# Altium schematic sheet Data_Acquisition.SchDoc
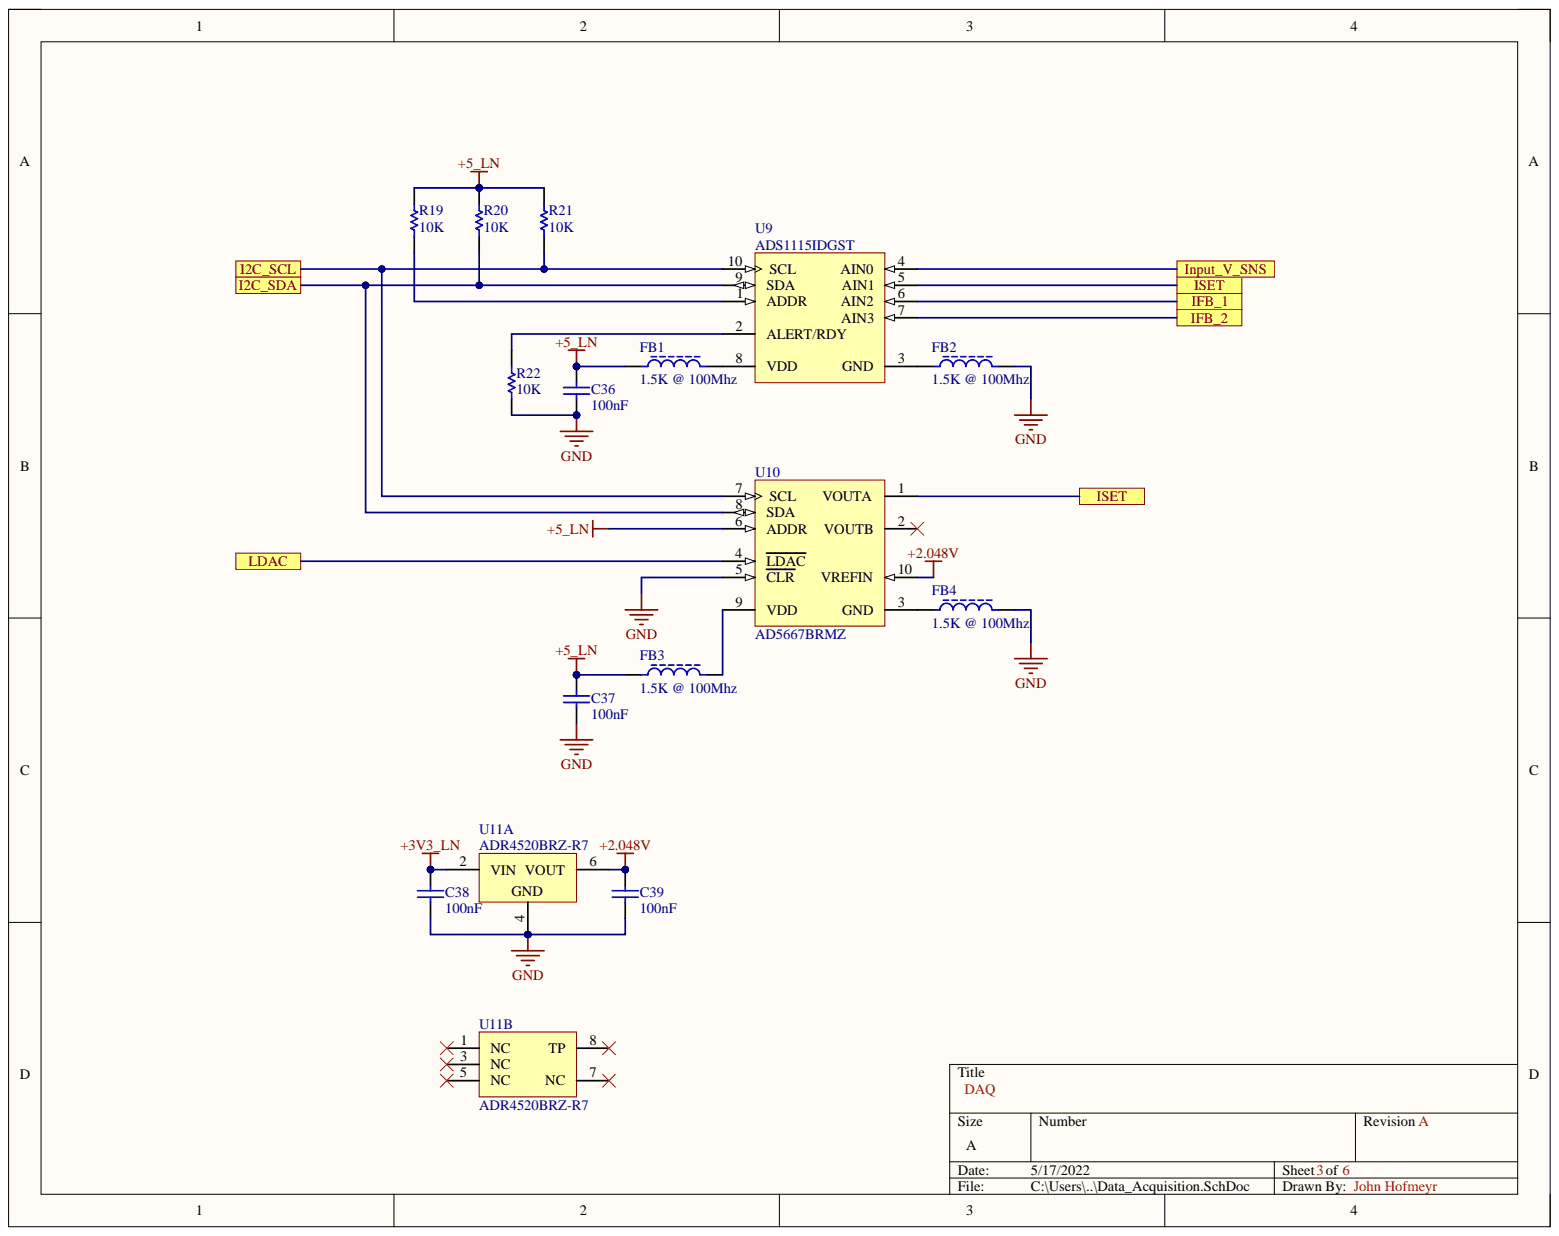
[diagram p0: Data_Acquisition.SchDoc]

## Parts
C36  100nF  [CAPC2013X140X50ML20T25]
C37  100nF  [CAPC2013X140X50ML20T25]
C38  100nF  [CAPC2013X140X50ML20T25]
C39  100nF  [CAPC2013X140X50ML20T25]
FB1  1.5K @ 100Mhz  [R0805]
FB2  1.5K @ 100Mhz  [R0805]
FB3  1.5K @ 100Mhz  [R0805]
FB4  1.5K @ 100Mhz  [R0805]
R19  10K  [RESC2013X50X40NL20T20]
R20  10K  [RESC2013X50X40NL20T20]
R21  10K  [RESC2013X50X40NL20T20]
R22  10K  [RESC2013X50X40NL20T20]
U9  ADS1115IDGST  [TI-DGS10_M]
U10  AD5667BRMZ  [RM-10_M]
U11  ADR4520BRZ-R7  [ADI-R-8_M]  (parts A,B)

## Ports
I2C_SCL, I2C_SDA, IFB_1, IFB_2, Input_V_SNS, ISET, LDAC

## Nets
+2.048V: C39.2 U10.10(VREFIN) U11.6(VOUT)
+3V3_LN: C38.2 U11.2(VIN)
+5_LN: C36.2 C37.2 FB1.1 FB3.1 R19.2 R20.2 R21.2 U10.6(ADDR)
GND: C36.1 C37.1 C38.1 C39.1 FB2.2 FB4.2 R22.2 U10.5(CLR) U11.4
I2C_SCL: R21.1 U9.10(SCL) U10.7(SCL) ; port I2C_SCL
I2C_SDA: R20.1 U9.9(SDA) U10.8(SDA) ; port I2C_SDA
IFB_1: U9.6(AIN2) ; port IFB_1
IFB_2: U9.7(AIN3) ; port IFB_2
Input_V_SNS: U9.4(AIN0) ; port Input_V_SNS
ISET: U9.5(AIN1) U10.1(VOUTA) ; port ISET
LDAC: U10.4(LDAC) ; port LDAC
NetFB1_2: FB1.2 U9.8(VDD)
NetFB2_1: FB2.1 U9.3(GND)
NetFB3_2: FB3.2 U10.9(VDD)
NetFB4_1: FB4.1 U10.3(GND)
NetR19_1: R19.1 U9.1(ADDR)
NetR22_1: R22.1 U9.2(ALERT/RDY)

Not connected: U10 2(VOUTB); U11 1(NC) 3(NC) 5(NC) 7(NC) 8(TP)

## Library data of the parts
C36-C39 = CMP-2007-04689-1: Manufacturer: AVX Interconnect / Elco; Part Number: 08055D104KAT2A; Component Kind: Standard; Library Name: Altium Content Vault
FB1-FB4 = MMZ2012Y152BTD25: FERRITE BEAD 1.5 KOHM 0805 1LN; Manufacturer: TDK; DC Resistance (DCR): 400mR; Impedance: 1.5kR; Max Current Rating: 500mA; Number of Lines: 1; Tolerance: 25%; Case Code (Imperial): 0805; Case Code (Metric): 2012; Case/Package: 0805; Category: Ferrite Beads and Chips; Component Kind: Standard; ComponentLink1Description: https://media.digikey.com/pdf/Data%20Sheets/TDK%20PDFs/MMZ_Series_Br.pdf?src-supplier=Digi-Key; ComponentLink1URL: https://media.digikey.com/pdf/Data%20Sheets/TDK%20PDFs/MMZ_Series_Br.pdf?src-supplier=Digi-Key; ComponentLink2Description: http://www.mouser.com/ds/2/400/beads_automotive_signal_mmz_en-469244.pdf?src-supplier=Mouser; ComponentLink2URL: http://www.mouser.com/ds/2/400/beads_automotive_signal_mmz_en-469244.pdf?src-supplier=Mouser; ComponentLink3Description: https://product.tdk.com/info/en/catalog/datasheets/beads_automotive_signal_mmz2012_en.pdf?src-supplier=Digi-Key; ComponentLink3URL: https://product.tdk.com/info/en/catalog/datasheets/beads_automotive_signal_mmz2012_en.pdf?src-supplier=Digi-Key; Height: 0.041inch; Length: 0.079inch; Library Name: DCLoadV2.SchLib; Manufacturer Part Number: MMZ2012Y152BTD25; Max DC Current: 500mA; Max Operating Temperature: 125°C; Min Operating Temperature: -55°C; Mount: Surface Mount; Package Quantity: 4000; Packaging: Tape and Reel; Pricing 1: 1=0.11000, 10=0.09100, 25=0.07080, 50=0.05860, 100=0.05060, 250=0.04048, 500=0.03644, 1000=0.03036 (USD); Ratings: AEC-Q200; RoHS: Yes; Stock 1: 4172; Supplier 1: Digi-Key; Supplier Part Number 1: 445-172871-1-ND; Termination: SMD/SMT; Test Frequency: 100MHz; Weight: 0.000194oz; Width: 0.049inch
R19-R22 = CMP-2001-03215-1: Manufacturer: Susumu; Part Number: RR1220P-103-D; Component Kind: Standard; Library Name: Altium Content Vault
U9 = CMP-0003-00378-2: 16-Bit ADC with Integrated Multiplexer, PGA, Comparator, Oscillator, and Reference, -40 to 125 degC, 10-pin SOP (DGS10), Green (RoHS & no Sb/Br); Manufacturer: Texas Instruments; # Input Channels: 4; Analog Voltage AV/DD(Max)(V): 5.5; Analog Voltage AV/DD(Min)(V): 2; Architecture: Delta-Sigma; INL(Max)(+/-LSB): 1; Interface: Serial I2C; Resolution(Bits): 16; Sample Rate (max)(SPS): 860; SubFamily: Precision ADC (<=10MSPS); Code_JEDEC: MO-153; Component Kind: Standard; ComponentLink1Description: Manufacturer URL; ComponentLink1URL: http://www.ti.com/; ComponentLink2Description: Datasheet; ComponentLink2URL: http://www.ti.com/general/docs/lit/getliterature.tsp?genericPartNumber=ADS1115&fileType=pdf; ComponentLink3Description: Package Specification; ComponentLink3URL: http://www.ti.com/lit/ml/mpds035b/mpds035b.pdf; DatasheetVersion: SBAS444B; Digital Supply(Max)(V): 5.5; Digital Supply(Min)(V): 2; Eco-Plan: Green (RoHS & no Sb/Br); Library Name: Altium Content Vault; Mounting Technology: Surface Mount; PackageDescription: 10-Pin Plastic Small Outline, Body 3 x 3 mm, Pitch 0.5 mm; PackageReference: DGS10; PackageVersion: 4073272/C, 02/2004; PartNumber: ADS1115IDGST
U10 = CMP-0318-02093-2: Dual 16-Bit nanoDAC with I2C Interface, -40 to +105 degC, RM-10, Pb-Free, Tube; Generic Part Number: AD5667; Manufacturer: Analog Devices; # DAC Outputs: 2; Max V+: 5.5V; Op Pwr Diss: 5.75mW; Resolution (Bits): 16; Settling Time: 4Âµs; Single Supply: Yes; Component Kind: Standard; ComponentLink1Description: Manufacturer URL; ComponentLink1URL: http://www.analog.com/en/index.html; ComponentLink2Description: Datasheet; ComponentLink2URL: http://www.analog.com/static/imported-files/data_sheets/AD5627R_5647R_5667R_5627_5667.pdf; ComponentLink3Description: Package Specification; ComponentLink3URL: http://www.analog.com/static/imported-files/packages/PKG_PDF/MSOP%28RM%29/RM_10.pdf; ComponentLink4Description: Product URL; ComponentLink4URL: http://www.analog.com/en/digital-to-analog-converters/da-converters/ad5667/products/product.html; DatasheetVersion: Rev.0; Library Name: Altium Content Vault; Mounting Technology: Surface Mount; PackageDescription: 10-Lead Mini Small Outline Package [MSOP]; PackageReference: RM-10; PackageVersion: May-2005; Packing: Tube; PartNumber: AD5667BRMZ; RevisionNote: Component URL fixed; RoHS: Y
U11 = CMP-0784-00295-1: Ultralow Noise, High Accuracy Voltage Reference, 2.048 V Output, 3 to 15 V Supply, Industrial, 8-pin SOIC (R-8), Reel; Manufacturer: Analog Devices; Max V Supply (V): 15; Min V Supply (V): 3; Ref Out TC (ppm/C): 2; Vout: 2.048V; Code_JEDEC: MS-012-AA; Component Kind: Standard; ComponentLink1Description: Manufacturer URL; ComponentLink1URL: http://www.analog.com/en/index.html; ComponentLink2Description: Datasheet; ComponentLink2URL: http://www.analog.com/static/imported-files/data_sheets/ADR4520_4525_4530_4533_4540_4550.pdf; ComponentLink3Description: Package Specification; ComponentLink3URL: http://www.analog.com/static/imported-files/packages/PKG_PDF/SOIC_Narrow%20(R)/R_8.pdf; ComponentLink4Description: URL Link1; ComponentLink4URL: Product URL:http://www.analog.com/en/special-linear-functions/voltage-references/adr4520/products/product.html; DatasheetVersion: Rev. 0; Library Name: Altium Content Vault; Mounting Technology: Surface Mount; Operating Temp Range: -40 to 125 degC; PackageDescription: 8-Pin Standard Small Outline Package, Body 4.9 x 3.9 mm, Pitch 1.27 mm; PackageReference: R-8; PackageVersion: 060506-A, 06/2006; Packing: Reel; PartNumber: ADR4520BRZ-R7
